# Altium schematic sheet mcu.SchDoc(mcu)
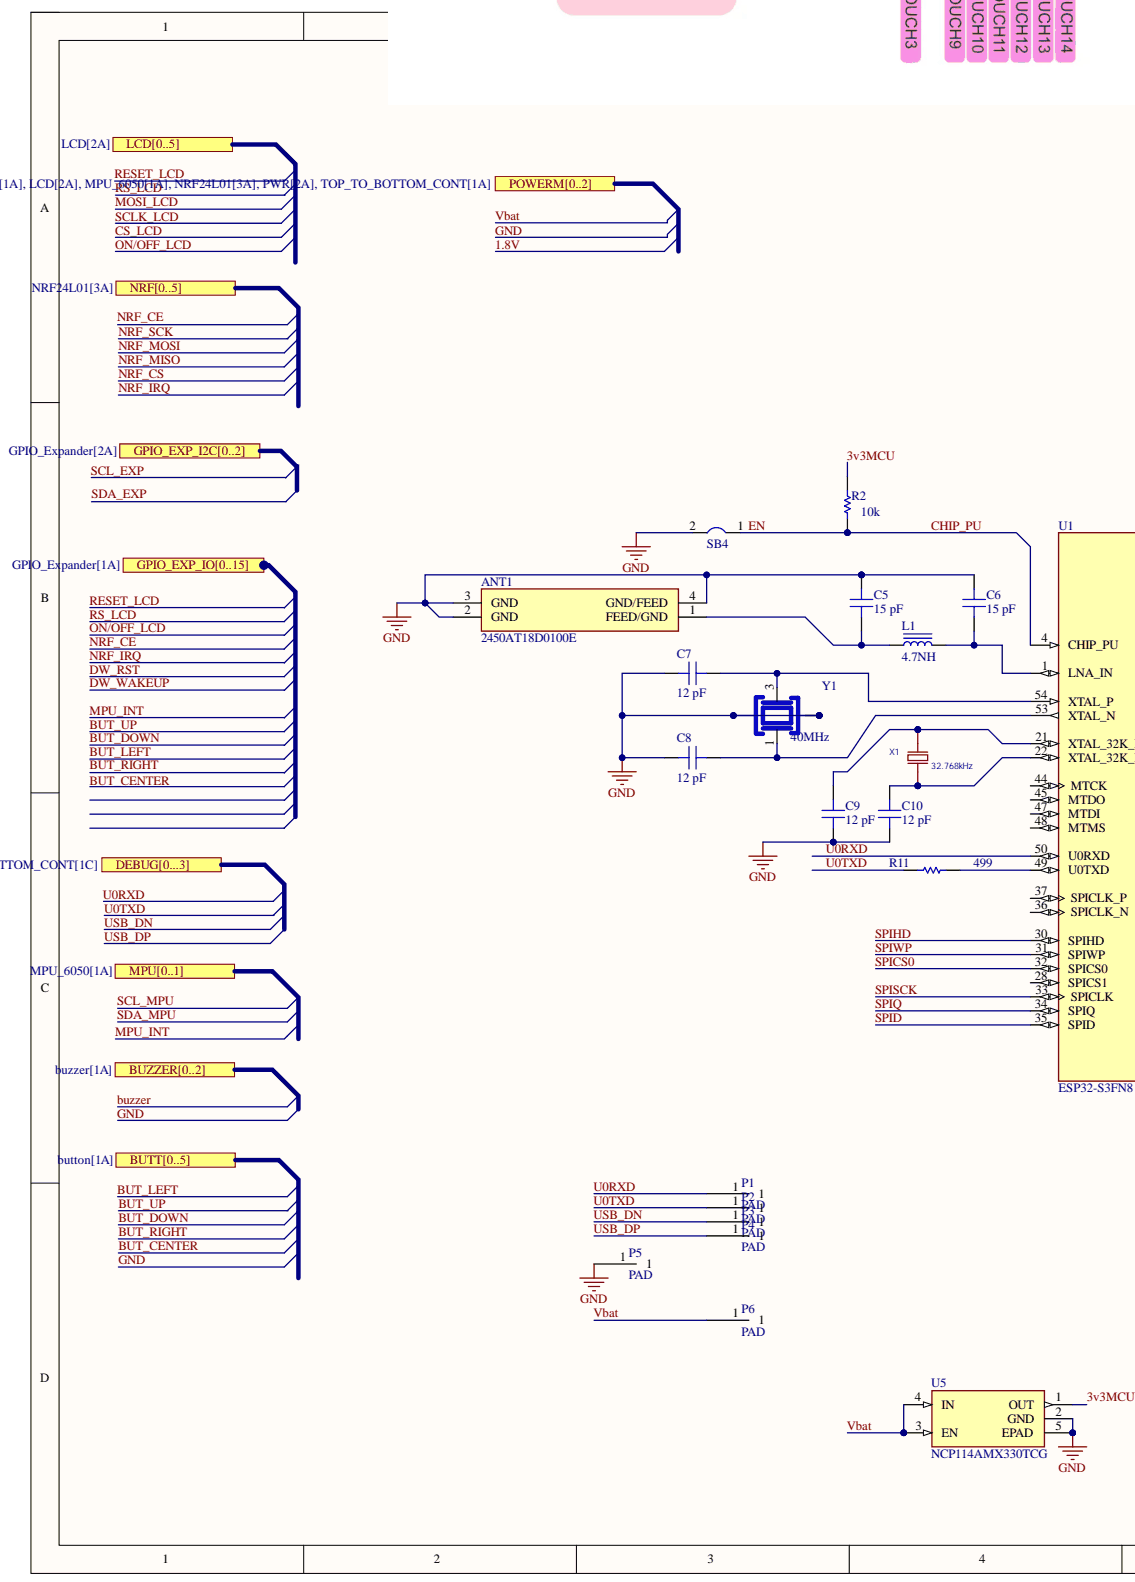
[diagram p0: mcu.SchDoc, left]
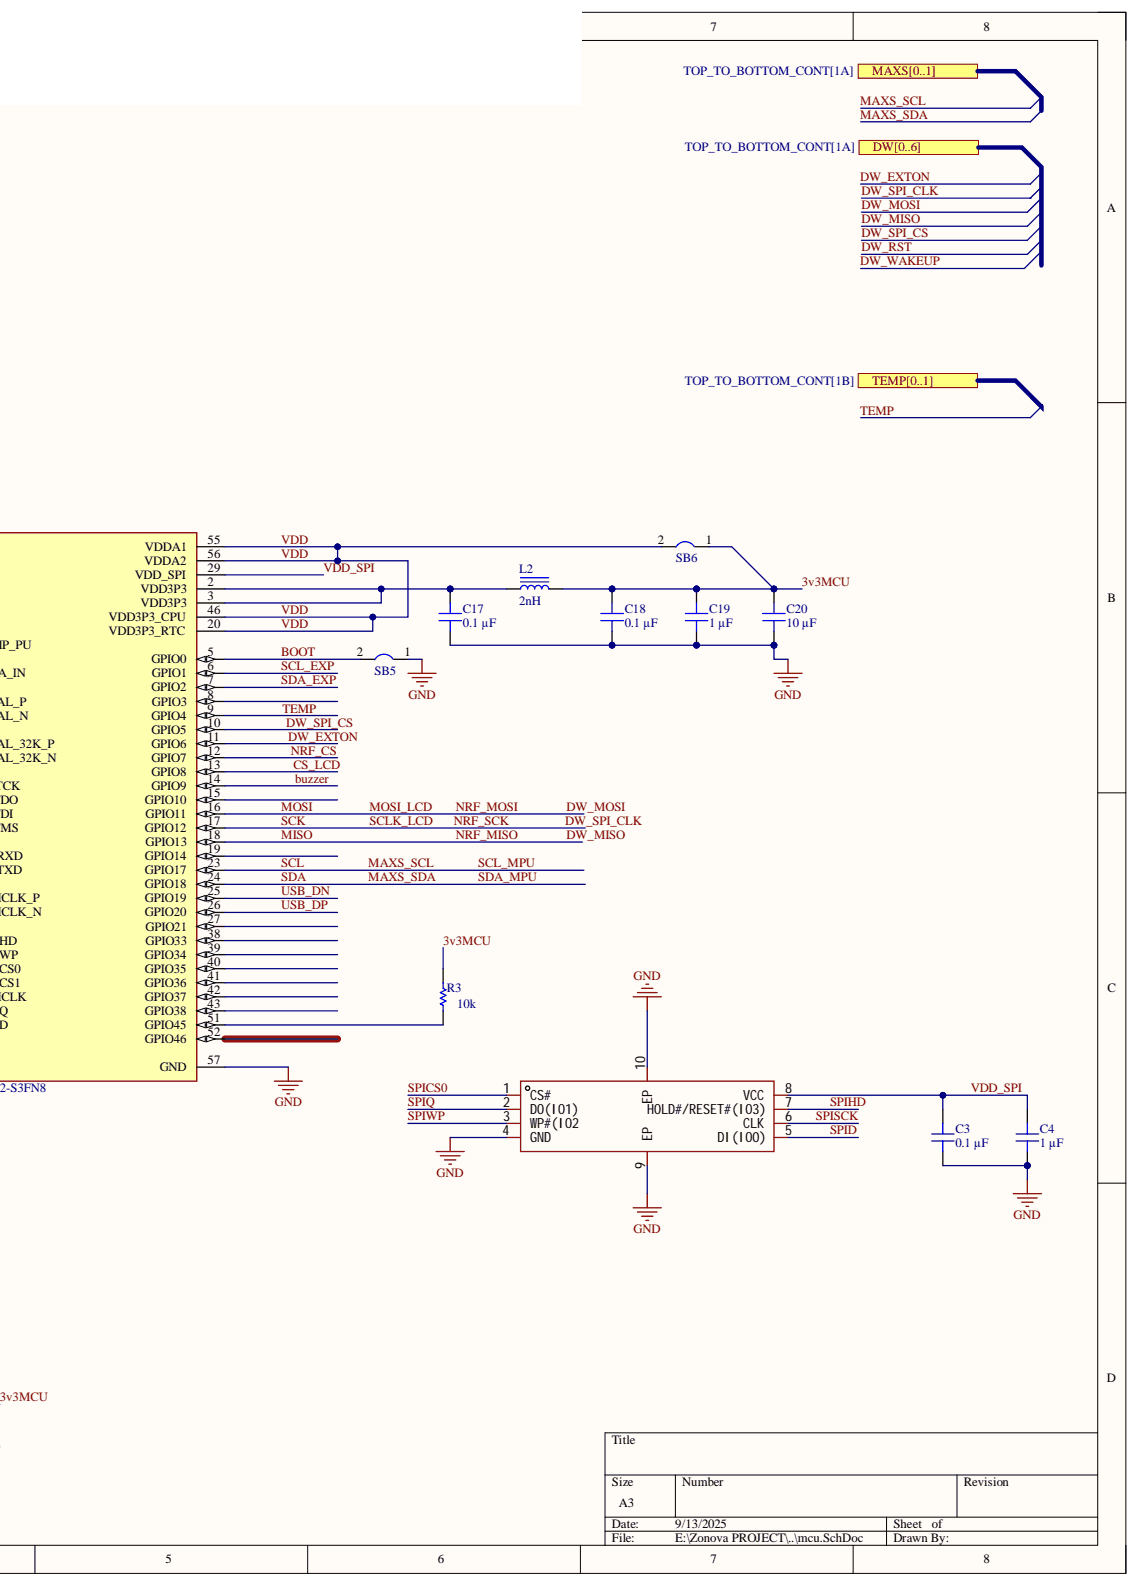
[diagram p1: mcu.SchDoc, right]

## Parts
ANT1  2450AT18D0100E  [FP-2450AT18D0100E-MFG]
C3  Capacitance: 0.1 µF  [CAP 0402_1005]
C4  Capacitance: 1 µF  [CAP 0402_1005]
C5  Capacitance: 15 pF  [CAP 0402_1005]
C6  Capacitance: 15 pF  [CAP 0402_1005]
C7  Capacitance: 12 pF  [CAP 0402_1005]
C8  Capacitance: 12 pF  [CAP 0402_1005]
C9  =Value  [CAP 0402_1005]
C10  Capacitance: 12 pF  [CAP 0402_1005]
C17  Capacitance: 0.1 µF  [CAP 0402_1005]
C18  Capacitance: 0.1 µF  [CAP 0402_1005]
C19  Capacitance: 1 µF  [CAP 0402_1005]
C20  Capacitance: 10 µF  [CAP 0402_1005]
L1  4.7NH  [Murata LQG15 0402]
L2  2nH  [IND 0402_1005]
P1  PAD  [PCBComponent_1]
P2  PAD  [PCBComponent_1]
P3  PAD  [PCBComponent_1]
P4  PAD  [PCBComponent_1]
P5  PAD  [PCBComponent_1]
P6  PAD  [PCBComponent_1]
R2  resistance: 10k  [RESC1005X40X25LL05T10]  (hidden comment: 0402WGF1002TCE)
R3  resistance: 10k  [RESC1005X40X25LL05T10]  (hidden comment: 0402WGF1002TCE)
R11  TNPW0402499RBEED  [RESC1005X40X20LL05T10]  (value not drawn)
SB4  Jumper  [Jumper]  (value not drawn)
SB5  Jumper  [Jumper]  (value not drawn)
SB6  Jumper  [Jumper]  (value not drawn)
U1  ESP32-S3FN8  [QFN40P700X700X90-57N]
U3  W25Q64JWUUIQ  [USON-8_L4.0-W3.0-P0.80-BL_WINBOND_UU]  (value not drawn)
U5  NCP114AMX330TCG  [REG_NCP114AMX330TCG]
X1  32.768kHz  [FC-135R_L3.2-W1.5]
Y1  value 40MHz  [C5261125]  (hidden comment: L225S400L11L)

## Ports
BUTT0, BUTT1, BUTT2, BUTT3, BUTT4, BUTT5, BUTT[0..5], BUZZER0, BUZZER1, BUZZER2, BUZZER[0..2], DEBUG[0...3], DW0, DW1, DW2, DW3, DW4, DW5, DW6, DW[0..6], GPIO_EXP_I2C0, GPIO_EXP_I2C1, GPIO_EXP_I2C2, GPIO_EXP_I2C[0..2], GPIO_EXP_IO0, GPIO_EXP_IO1, GPIO_EXP_IO2, GPIO_EXP_IO3, GPIO_EXP_IO4, GPIO_EXP_IO5, GPIO_EXP_IO6, GPIO_EXP_IO7, GPIO_EXP_IO8, GPIO_EXP_IO9, GPIO_EXP_IO10, GPIO_EXP_IO11, GPIO_EXP_IO12, GPIO_EXP_IO13, GPIO_EXP_IO14, GPIO_EXP_IO15, GPIO_EXP_IO[0..15], LCD0, LCD1, LCD2, LCD3, LCD4, LCD5, LCD[0..5], MAXS0, MAXS1, MAXS[0..1], MPU0, MPU1, MPU[0..1], NRF0, NRF1, NRF2, NRF3, NRF4, NRF5, NRF[0..5], POWERM0, POWERM1, POWERM2, POWERM[0..2], TEMP0, TEMP1, TEMP[0..1]

## Nets
3v3MCU: C18.2 C19.2 C20.2 L2.2 R2.2 R3.2 SB6.1 U5.1(OUT)
BOOT: SB5.2 U1.5(GPIO0)
buzzer: U1.14(GPIO9)
CHIP_PU: R2.1 SB4.1 U1.4(CHIP_PU)
CS_LCD: U1.13(GPIO8)
DW_EXTON: U1.11(GPIO6)
DW_MISO: U1.18(GPIO13)
DW_MOSI: U1.16(GPIO11)
DW_SPI_CLK: U1.17(GPIO12)
DW_SPI_CS: U1.10(GPIO5)
GND: ANT1.2(GND) ANT1.3(GND) ANT1.4(GND/FEED) C3.1 C4.1 C5.2 C6.2 C7.1 C8.1 C9.2 C10.2 C17.1 C18.1 C19.1 C20.1 P5.1 SB4.2 SB5.1 U1.57(GND) U3.4(GND) U3.9(EP) U3.10(EP) U5.2(GND) U5.5(EPAD) Y1.2 Y1.4
MAXS_SCL: U1.23(GPIO17)
MAXS_SDA: U1.24(GPIO18)
NRF_CS: U1.12(GPIO7)
SCL_EXP: U1.6(GPIO1)
SDA_EXP: U1.7(GPIO2)
SPICS0: U1.32(SPICS0) U3.1(CS#)
SPID: U1.35(SPID) U3.5(DI(IO0))
SPIHD: U1.30(SPIHD) U3.7(HOLD#/RESET#(IO3))
SPIQ: U1.34(SPIQ) U3.2(DO(IO1))
SPISCK: U1.33(SPICLK) U3.6(CLK)
SPIWP: U1.31(SPIWP) U3.3(WP#(IO2)
TEMP: U1.9(GPIO4)
U0RXD: P1.1 U1.50(U0RXD)
U0TXD: P2.1 R11.1
USB_DN: P3.1 U1.25(GPIO19)
USB_DP: P4.1 U1.26(GPIO20)
Vbat: P6.1 U5.3(EN) U5.4(IN)
VDD: SB6.2 U1.20(VDD3P3_RTC) U1.46(VDD3P3_CPU) U1.55(VDDA1) U1.56(VDDA2)
VDD_SPI: C3.2 C4.2 U1.29(VDD_SPI) U3.8(VCC)
NetANT1_1: ANT1.1(FEED/GND) C5.1 L1.1
NetC6_1: C6.1 L1.2 U1.1(LNA_IN)
NetC7_2: C7.2 U1.54(XTAL_P) Y1.3
NetC8_2: C8.2 U1.53(XTAL_N) Y1.1
NetC9_1: C9.1 U1.21(XTAL_32K_P) X1.2
NetC10_1: C10.1 U1.22(XTAL_32K_N) X1.1
NetC17_2: C17.2 L2.1 U1.2(VDD3P3) U1.3(VDD3P3)
NetR3_1: R3.1 U1.51(GPIO45)
NetR11_2: R11.2 U1.49(U0TXD)
1.8V:
BUT_CENTER:
BUT_DOWN:
BUT_LEFT:
BUT_RIGHT:
BUT_UP:
DW_RST:
DW_WAKEUP:
MPU_INT:
N00002_0: ; port LCD[0..5]
N00002_1: ; port LCD[0..5]
N00002_2: ; port LCD[0..5]
N00002_3: ; port LCD[0..5]
N00002_4: ; port LCD[0..5]
N00002_5: ; port LCD[0..5]
N00004_0: ; port POWERM[0..2]
N00004_1: ; port POWERM[0..2]
N00004_2: ; port POWERM[0..2]
N00010_[0..-1]: ; port DEBUG[0...3]
N00012_0: ; port MAXS[0..1]
N00012_1: ; port MAXS[0..1]
N00014_0: ; port GPIO_EXP_I2C[0..2]
N00014_1: ; port GPIO_EXP_I2C[0..2]
N00014_2: ; port GPIO_EXP_I2C[0..2]
N00016_0: ; port MPU[0..1]
N00016_1: ; port MPU[0..1]
N00018_0: ; port TEMP[0..1]
N00018_1: ; port TEMP[0..1]
N00020_0: ; port BUTT[0..5]
N00020_1: ; port BUTT[0..5]
N00020_2: ; port BUTT[0..5]
N00020_3: ; port BUTT[0..5]
N00020_4: ; port BUTT[0..5]
N00020_5: ; port BUTT[0..5]
N00022_0: ; port BUZZER[0..2]
N00022_1: ; port BUZZER[0..2]
N00022_2: ; port BUZZER[0..2]
N00024_0: ; port GPIO_EXP_IO[0..15]
N00024_1: ; port GPIO_EXP_IO[0..15]
N00024_2: ; port GPIO_EXP_IO[0..15]
N00024_3: ; port GPIO_EXP_IO[0..15]
N00024_4: ; port GPIO_EXP_IO[0..15]
N00024_5: ; port GPIO_EXP_IO[0..15]
N00024_6: ; port GPIO_EXP_IO[0..15]
N00024_7: ; port GPIO_EXP_IO[0..15]
N00024_8: ; port GPIO_EXP_IO[0..15]
N00024_9: ; port GPIO_EXP_IO[0..15]
N00024_10: ; port GPIO_EXP_IO[0..15]
N00024_11: ; port GPIO_EXP_IO[0..15]
N00024_12: ; port GPIO_EXP_IO[0..15]
N00024_13: ; port GPIO_EXP_IO[0..15]
N00024_14: ; port GPIO_EXP_IO[0..15]
N00024_15: ; port GPIO_EXP_IO[0..15]
N00026_0: ; port NRF[0..5]
N00026_1: ; port NRF[0..5]
N00026_2: ; port NRF[0..5]
N00026_3: ; port NRF[0..5]
N00026_4: ; port NRF[0..5]
N00026_5: ; port NRF[0..5]
N00028_0: ; port DW[0..6]
N00028_1: ; port DW[0..6]
N00028_2: ; port DW[0..6]
N00028_3: ; port DW[0..6]
N00028_4: ; port DW[0..6]
N00028_5: ; port DW[0..6]
N00028_6: ; port DW[0..6]
NRF_CE:
NRF_IRQ:
ON/OFF_LCD:
RESET_LCD:
RS_LCD:

Not connected: U1 8(GPIO3) 15(GPIO10) 19(GPIO14) 27(GPIO21) 28(SPICS1) 36(SPICLK_N) 37(SPICLK_P) 38(GPIO33) 39(GPIO34) 40(GPIO35) 41(GPIO36) 42(GPIO37) 43(GPIO38) 44(MTCK) 45(MTDO) 47(MTDI) 48(MTMS) 52(GPIO46)

## Library data of the parts
ANT1 = CMP-2000-05543-2: RF ANT 2.4GHZ CHIP SOLDER SMD; Manufacturer: Johanson Dielectrics; Bandwidth: 100MHz; Frequency: 2.45GHz; Gain: -1dB; Impedance: 50R; Max Power Dissipation: 2W; Number of Bands: 1; Return Loss: -10dB; Case/Package: SMD/SMT; Component Kind: Standard; Height: 0.047inch; JLCPCB Part #: C2917718; Length: 3.2mm; Library Name: Altium Content Vault; MFR.Part #: 2450AT18D0100E; Mount: Surface Mount; Package Quantity: 3000; Packaging: Tape and Reel; Power Rating: 2W; Radiation Hardening: No; RoHS Compliant: Yes; Series: -; Termination: Solder; Width: 1.6mm
C3 C17 C18 = 0402B104J160CT: 100nF 16V X7R ±10% 0402 Multilayer Ceramic Capacitors MLCC - SMD/SMT ROHS; Manufacturer: YAGEO; MFR.Part #: CC0402KRX7R7BB104; Database Table Name: Capacitors - Ceramic - 0402; Comment: =Value; Component Kind: Standard; Device Package: 0402 (1005 Metric); JLCPCB Part #: C60474; Library Name: Celestial Altium Library - altium_library.DbLib; Size / Dimension: 0.039" L x 0.020" W (1.00mm x 0.50mm)
C4 C19 = 0402DD105KAT2A: 10V 1uF X5R ±10% 0402 Multilayer Ceramic Capacitors MLCC - SMD/SMT ROHS; Manufacturer: Samsung Electro-Mechanics; MFR.Part #: CL05A105KP5NNNC; Database Table Name: Capacitors - Ceramic - 0402; Comment: =Value; Component Kind: Standard; Device Package: 0402 (1005 Metric); JLCPCB Part #: C14445; Library Name: Celestial Altium Library - altium_library.DbLib; Part Number: CL05A105KP5NNNC; Size / Dimension: 0.039" L x 0.020" W (1.00mm x 0.50mm)
C5 C6 = 201R07S150GV4T: CAP CER 15PF 200V C0G/NP0 0402; Manufacturer: FH (Guangdong Fenghua Advanced Tech); MFR.Part #: 0402CG150J500NT; Database Table Name: Capacitors - Ceramic - 0402; Comment: =Value; Component Kind: Standard; Device Package: 0402 (1005 Metric); JLCPCB Part #: C1548; Library Name: Celestial Altium Library - altium_library.DbLib; Mounting Type: Surface Mount, MLCC; Part Number: 0402CG150J500NT; Size / Dimension: 0.040" L x 0.020" W (1.02mm x 0.51mm)
  C6: Applications: RF, Microwave, High Frequency
C7-C10 = 201R07S120GV4T: CAP CER 12PF 200V C0G/NP0 0402; Manufacturer: FH (Guangdong Fenghua Advanced Tech); MFR.Part #: 0402CG120J500NT; Database Table Name: Capacitors - Ceramic - 0402; Applications: RF, Microwave, High Frequency; Component Kind: Standard; Device Package: 0402 (1005 Metric); JLCPCB Part #: C1547; Library Name: Celestial Altium Library - altium_library.DbLib; Mounting Type: Surface Mount, MLCC; Part Number: 0402CG120J500NT; Size / Dimension: 0.040" L x 0.020" W (1.02mm x 0.51mm)
  C7 C8 C10: Comment: =Value
  C9: Capacitance: 12 pF
C20 = 0402DD105KAT2A: 10V 1uF X5R ±10% 0402 Multilayer Ceramic Capacitors MLCC - SMD/SMT ROHS; Manufacturer: Samsung Electro-Mechanics; MFR.Part #: CL05A106MQ5NUNC; Part Number: CL05A105KP5NNNC; Database Table Name: Capacitors - Ceramic - 0402; Comment: =Value; Component Kind: Standard; Device Package: 0402 (1005 Metric); JLCPCB Part #: C15525; Library Name: Celestial Altium Library - altium_library.DbLib; Size / Dimension: 0.039" L x 0.020" W (1.00mm x 0.50mm)
L1 = LQG15HN4N7B02D: 200mΩ 300mA 4.7nH 4GHz 8@100MHz 0402 Inductors (SMD) ROHS; Manufacturer: Sunlord; MFR.Part #: SDCL1005C4N7STDF; Database Table Name: Inductors - RF; Component Kind: Standard; JLCPCB Part #: C13595; Library Name: Celestial Altium Library - altium_library.DbLib; package: 0402; value: 4.7NH
L2 = HLC022R0BTTR: FIXED IND 2NH 596MA 180 MOHM SMD; Manufacturer: AVX Corporation; Database Table Name: Inductors - Power; DC Resistance (DCR): 180mOhm Max; Frequency - Self Resonant: 17GHz; Inductance Frequency - Test: 450MHz; Material - Core: Non-Magnetic; Q @ Freq: 26 @ 450MHz; Shielding: Unshielded; Tolerance: ±0.1nH; Type: Multilayer; Component Kind: Standard; ComponentLink1Description: Datasheet; ComponentLink1URL: //media.digikey.com/pdf/Data%20Sheets/AVX%20PDFs/MLO%20High%20Current%20Inductors.pdf; ComponentLink2Description: DigiKey Link; ComponentLink2URL: http://digikey.com/product-detail/en/avx-corporation/HLC022R0BTTR/478-7195-1-ND/3528423; Current Rating (Amps): 596mA; Device Package: 0402 (1005 Metric); Height - Seated (Max): 0.018" (0.45mm); Inductance: 2nH; LastUpdated: 9/2/2020 1:24:25 AM; Library Name: Celestial Altium Library - altium_library.DbLib; Lifecycle Status: Active; Minimum Order: 1; Mounting Type: Surface Mount; Operating Temperature: -55°C ~ 125°C; Package / Case: 0402 (1005 Metric); Packaging: TapeAndReel; Part Number: HLC022R0BTTR; Part Status: Active; PartId: 1747; Price: 0.14; Series: MLO™; Size / Dimension: 0.039" L x 0.023" W (1.00mm x 0.58mm); Supplier 1: DigiKey; Supplier Device Package: 0402 (1005 Metric); Supplier Part Number 1: 478-7195-1-ND; Value: 2nH
R2 R3 = CMP-2002-03043-1: Manufacturer: UNI-ROYAL(Uniroyal Elec); Component Kind: Standard; JLCPCB Part #: C25744; Library Name: Altium Content Vault; MFR.Part #: 0402WGF1002TCE; Part Number: 0402WGF1002TCE
R11 = CMP-2002-01693-1: Manufacturer: YAGEO; MFR.Part #: RC0402FR-07499RL; resistance: 499; Component Kind: Standard; JLCPCB Part #: C137970; Library Name: Altium Content Vault; Part Number: RC0402FR-07499RL
U1 = ESP32-S3FN8: Espressif Systems [ENGINEERING SAMPLES]SMD IC , Dual-Core MCU, Wi-Fi 2.4G & BLE 5.0 combo, 8 MB Octal SPI PSRAM, QFN 56-pin, 7*7 mm; Check_prices: https://www.snapeda.com/parts/ESP32-S3FN8/Espressif+Systems/view-part/?ref=eda; Component Kind: Standard; Library Name: ESP32-S3FN8.IntLib; MF: Espressif Systems; MP: ESP32-S3FN8; Package: None; SnapEDA_Link: https://www.snapeda.com/parts/ESP32-S3FN8/Espressif+Systems/view-part/?ref=snap
U3 = W25Q64JWUUIQ: Manufacturer: Winbond(华邦); Add into BOM: yes; Clock Frequency: 133MHz; Data Retention - TDR (Year): 20 Years; Interface: SPI; Memory Size: 64Mbit; Page Programming Time (Tpp): 800us; Program / Erase Cycles: 100,000 Cycles; Voltage - Supply: 1.7V~1.95V; Component Kind: Standard; Convert to PCB: yes; Datasheet: https://atta.szlcsc.com/upload/public/pdf/source/20210420/C2595075_E7A7725B7CF2DE50C07916BF10CAF3A1.pdf; Device: W25Q64JWUUIQ; JLCPCB Part Class: Extended Part; LCSC Part Name: W25Q64JWUUIQ; Manufacturer Part: W25Q64JWUUIQ; Operating Temperature: -; PCB3D: USON-8_L4.0-W3.0-H0.6-P0.80-BL_GD25Q32CNIGR; Supplier: LCSC; Supplier Footprint: USON-8(3x4); Supplier Part: C6604692; Symbol: W25Q64JWUUIQ
U5 = NCP114AMX330TCG: Linear Voltage Regulator IC Positive Fixed 1 Output 300mA 4-UDFN (1.0x1.0); MF: ON Semiconductor; Check_prices: https://www.snapeda.com/parts/NCP114AMX330TCG/Onsemi/view-part/?ref=eda; Component Kind: Standard; Library Name: NCP114AMX330TCG.IntLib; MP: NCP114AMX330TCG; Package: UDFN-4 ON Semiconductor; SnapEDA_Link: https://www.snapeda.com/parts/NCP114AMX330TCG/Onsemi/view-part/?ref=snap
X1 = Q13FC13500004_C32346: Manufacturer: Seiko Epson; Component Kind: Standard; JLCPCB Part #: C32346; MFR.Part #: Q13FC13500004; PCB3D: FC-135R_L3.2-W1.5; Value: 32.768kHz
Y1 = L225S400L11L: -40℃~+85℃ 12pF 40MHz Crystal Oscillator ±10ppm SMD2520-4P Crystals ROHS; Manufacturer: Lucki; Component Kind: Standard; JLCPCB Part #: C5261125; Library Name: C5261125.IntLib; MFR.Part #: L225S400L11L; Package: SMD2520-4P
P1-P6 = PAD: Component Kind: Standard; Library Name: PAD.IntLib
SB4-SB6 = Jumper: Component Kind: Standard; Library Name: Integrated_Library2.IntLib
